# Altium schematic sheet Esquematico.SchDoc
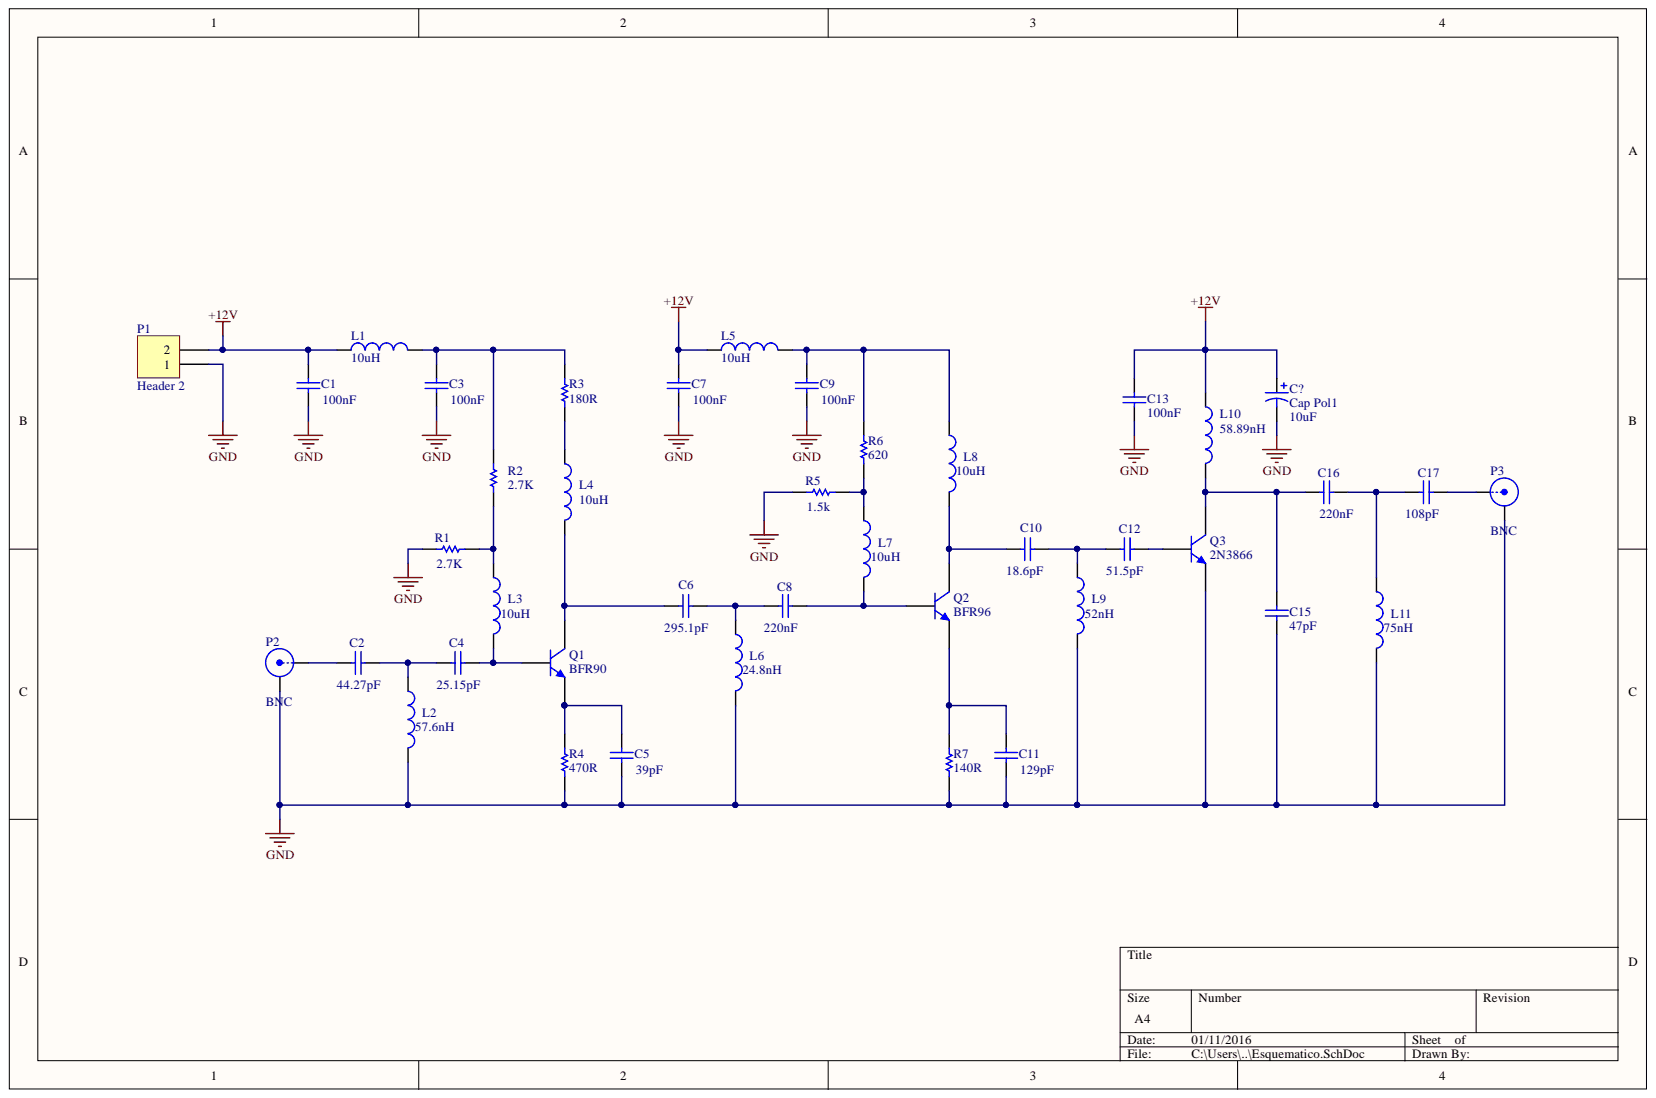
[diagram p0: Esquematico.SchDoc]

## Parts
C1  value 100nF  [6-0805_M]  (hidden comment: Cap)
C2  value 44.27pF  [6-0805_M]  (hidden comment: Cap)
C3  value 100nF  [6-0805_M]  (hidden comment: Cap)
C4  value 25.15pF  [6-0805_M]  (hidden comment: Cap)
C5  value 39pF  [6-0805_M]  (hidden comment: Cap)
C6  value 295.1pF  [6-0805_M]  (hidden comment: Cap)
C7  value 100nF  [6-0805_M]  (hidden comment: Cap)
C8  value 220nF  [6-0805_M]  (hidden comment: Cap)
C9  value 100nF  [6-0805_M]  (hidden comment: Cap)
C10  value 18.6pF  [6-0805_M]  (hidden comment: Cap)
C11  value 129pF  [6-0805_M]  (hidden comment: Cap)
C12  value 51.5pF  [6-0805_M]  (hidden comment: Cap)
C13  value 100nF  [6-0805_M]  (hidden comment: Cap)
C15  value 47pF  [6-0805_M]  (hidden comment: Cap)
C16  value 220nF  [6-0805_M]  (hidden comment: Cap)
C17  value 108pF  [6-0805_M]  (hidden comment: Cap)
C?  Cap Pol1  value 10uF  [RB7.6-15]
L1  value 10uH  [2029]  (hidden comment: Inductor)
L2  value 57.6nH  [2029]  (hidden comment: Inductor)
L3  value 10uH  [2029]  (hidden comment: Inductor)
L4  value 10uH  [2029]  (hidden comment: Inductor)
L5  value 10uH  [2029]  (hidden comment: Inductor)
L6  value 24.8nH  [2029]  (hidden comment: Inductor)
L7  value 10uH  [2029]  (hidden comment: Inductor)
L8  value 10uH  [2029]  (hidden comment: Inductor)
L9  value 52nH  [2029]  (hidden comment: Inductor)
L10  value 58.89nH  [2029]  (hidden comment: Inductor)
L11  value 75nH  [2029]  (hidden comment: Inductor)
P1  Header 2  [HDR1X2]
P2  BNC  [MMCX3.4-4V3]
P3  BNC  [MMCX3.4-4V3]
Q1  BFR90  [SOT323_N]
Q2  BFR96  [SOT323_N]
Q3  2N3866  [SOT323_N]
R1  value 2.7K  [6-0805_M]  (hidden comment: Res1)
R2  value 2.7K  [6-0805_M]  (hidden comment: Res1)
R3  value 180R  [6-0805_M]  (hidden comment: Res1)
R4  value 470R  [6-0805_M]  (hidden comment: Res1)
R5  value 1.5k  [6-0805_M]  (hidden comment: Res1)
R6  value 620  [6-0805_M]  (hidden comment: Res1)
R7  value 140R  [6-0805_M]  (hidden comment: Res1)

## Nets
+12V: C1.2 C7.2 C13.1 C?.1 L1.1 L5.1 L10.1 P1.2
GND: C1.1 C3.1 C5.1 C7.1 C9.1 C11.1 C13.2 C15.2 C?.2 L2.2 L6.2 L9.2 L11.2 P1.1 P2.2 P3.2 Q3.2 R1.1 R4.1 R5.1 R7.1
NetC2_1: C2.1 C4.2 L2.1
NetC2_2: C2.2 P2.1
NetC3_2: C3.2 L1.2 R2.2 R3.2
NetC4_1: C4.1 L3.2 Q1.1
NetC5_2: C5.2 Q1.2 R4.2
NetC6_1: C6.1 C8.2 L6.1
NetC6_2: C6.2 L4.2 Q1.3
NetC8_1: C8.1 L7.2 Q2.1
NetC9_2: C9.2 L5.2 L8.1 R6.2
NetC10_1: C10.1 C12.2 L9.1
NetC10_2: C10.2 L8.2 Q2.3
NetC11_2: C11.2 Q2.2 R7.2
NetC12_1: C12.1 Q3.1
NetC15_1: C15.1 C16.2 L10.2 Q3.3
NetC16_1: C16.1 C17.2 L11.1
NetC17_1: C17.1 P3.1
NetL3_1: L3.1 R1.2 R2.1
NetL4_1: L4.1 R3.1
NetL7_1: L7.1 R5.2 R6.1

## Library data of the parts
C1-C13 C15-C17 = Cap: Capacitor; Component Kind: Standard; LatestRevisionDate: 17-Jul-2002; LatestRevisionNote: Re-released for DXP Platform.; Library Name: Miscellaneous Devices.IntLib; PackageDescription: Radial Cap, Thru-Hole; 2 Leads; 0.3 in Pin Spacing; PackageReference: RAD-0.3; Published: 8-Jun-2000; Signal Integrity: Cap; Simulation: CAP; Publisher: Altium Limited
C? = Cap Pol1: Polarized Capacitor (Radial); Component Kind: Standard; LatestRevisionDate: 17-Jul-2002; LatestRevisionNote: Re-released for DXP Platform.; Library Name: Miscellaneous Devices.IntLib; PackageDescription: Polarized Capacitor (Radial); 2 Leads; PackageReference: RB7.6-15; Published: 8-Jun-2000; Signal Integrity: Cap Pol; Simulation: CAP; Publisher: Altium Limited
L1-L11 = Inductor: Code_IEC: 1005; Code_JEITA: 0402; Component Kind: Standard; Description: Inductor; LatestRevisionDate: 29-May-2009; LatestRevisionNote: IPC-7351 Footprint Added.; Library Name: Miscellaneous Devices.IntLib; PackageDescription: Chip Inductor; PackageReference: 0402-A; Published: 8-Jun-2000; Signal Integrity: Inductor; Simulation: INDUCTOR; Publisher: Altium Limited
P1 = Header 2: Header, 2-Pin; Component Kind: Standard; LatestRevisionDate: 17-Jul-2002; LatestRevisionNote: Re-released for DXP Platform.; Library Name: Miscellaneous Connectors.IntLib; Signal Integrity: Connector; Publisher: Altium Limited
P2 P3 = BNC: BNC Elbow Connector; Component Kind: Standard; LatestRevisionDate: 17-Jul-2002; LatestRevisionNote: Re-released for DXP Platform.; Library Name: Miscellaneous Connectors.IntLib; Signal Integrity: Connector; Publisher: Altium Limited
Q1-Q3 = BFR92AW: NPN 5 GHz Wideband Transistor; Component Kind: Standard; ComponentLink1Description: Manufacturer Link; ComponentLink1URL: http://www.semiconductors.philips.com/; ComponentLink2Description: Datasheet; ComponentLink2URL: http://www.semiconductors.philips.com/acrobat/datasheets/BFR92AW_2.pdf; DatasheetVersion: 18-Sep-1995; LatestRevisionDate: 12-Dec-2006; LatestRevisionNote: IPC-7351 Footprint Added.; Library Name: Philips Discrete BJT - RF Transistor.IntLib; PackageDescription: 3-Pin SOT-323 Package 0.65 mm Pitch, 2.1 mm Lead Span; PackageReference: SOT323; PackageVersion: Apr-1999; Published: 17-Sep-2003; Signal Integrity: BFR92AW; Simulation: BFR92AW; Publisher: Altium Limited
R1-R7 = Res1: Resistor; Component Kind: Standard; LatestRevisionDate: 17-Jul-2002; LatestRevisionNote: Re-released for DXP Platform.; Library Name: Miscellaneous Devices.IntLib; PackageDescription: Axial Device, Thru-Hole; 2 Leads; 0.3 in Pin Spacing; PackageReference: AXIAL-0.3; Published: 8-Jun-2000; Signal Integrity: Res; Simulation: RESISTOR; Publisher: Altium Limited
